# Altium schematic sheet LIDO-HW.100.OutputStage.SchDoc(LIDO-HW.100.OutputStage)
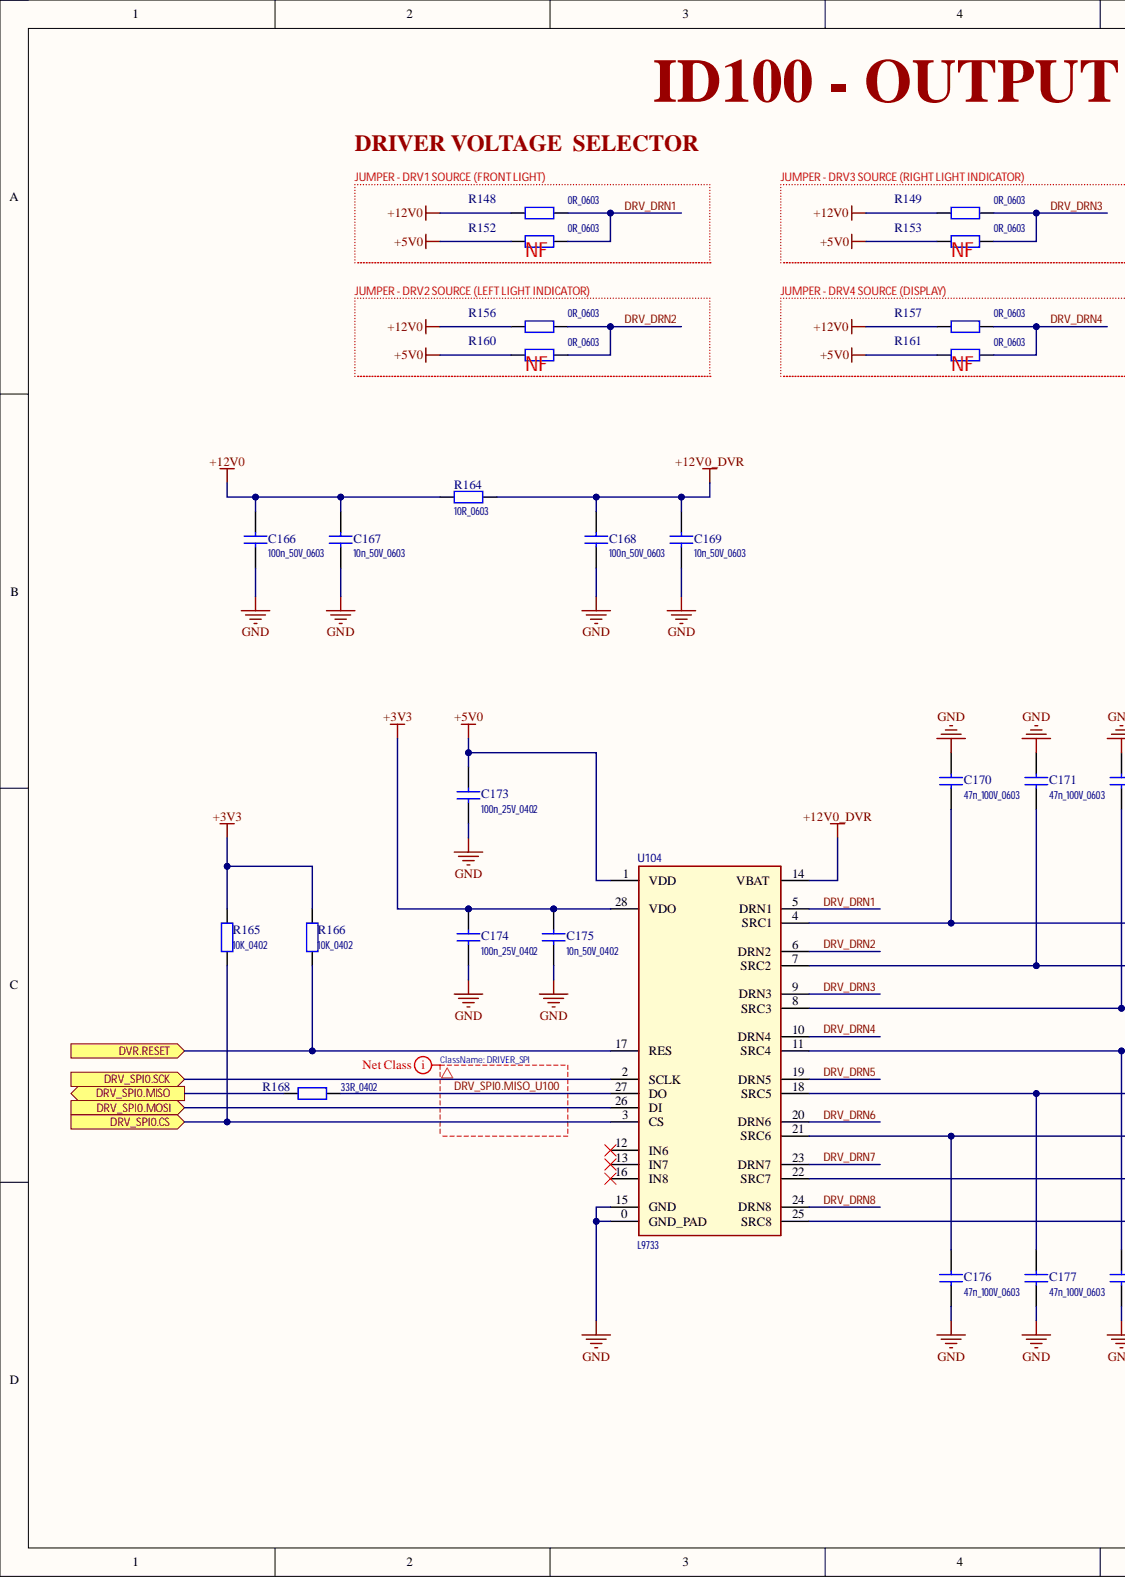
[diagram p0: LIDO-HW.100.OutputStage.SchDoc, left]
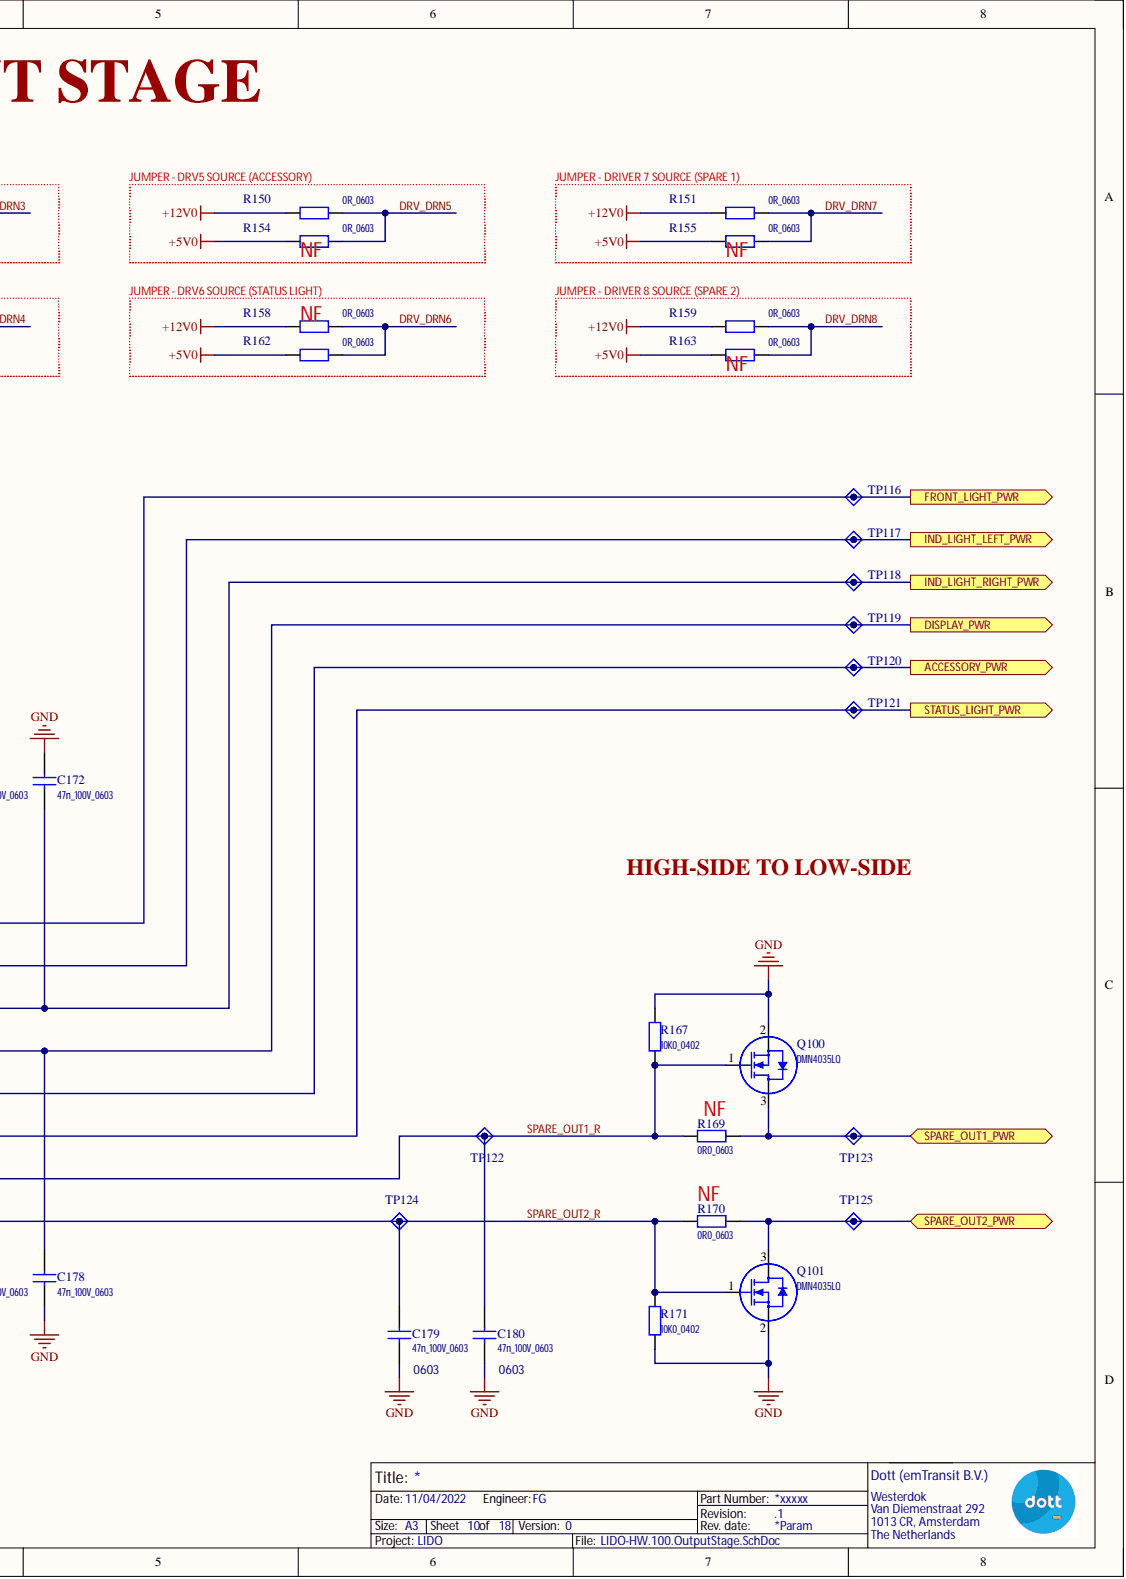
[diagram p1: LIDO-HW.100.OutputStage.SchDoc, right]

## Parts
C166  100n_50V_0603
C167  10n_50V_0603
C168  100n_50V_0603
C169  10n_50V_0603
C170  47n_100V_0603
C171  47n_100V_0603
C172  47n_100V_0603
C173  100n_25V_0402
C174  100n_25V_0402
C175  10n_50V_0402
C176  47n_100V_0603
C177  47n_100V_0603
C178  47n_100V_0603
C179  47n_100V_0603
C180  47n_100V_0603
Q100  DMN4035LQ
Q101  DMN4035LQ
R148  0R_0603
R149  0R_0603
R150  0R_0603
R151  0R_0603
R152  0R_0603
R153  0R_0603
R154  0R_0603
R155  0R_0603
R156  0R_0603
R157  0R_0603
R158  0R_0603
R159  0R_0603
R160  0R_0603
R161  0R_0603
R162  0R_0603
R163  0R_0603
R164  10R_0603
R165  10K_0402
R166  10K_0402
R167  10K0_0402
R168  33R_0402
R169  0R0_0603
R170  0R0_0603
R171  10K0_0402
TP116  TestPoint_PWR  (value not drawn)  (no BOM)
TP117  TestPoint_PWR  (value not drawn)  (no BOM)
TP118  TestPoint_PWR  (value not drawn)  (no BOM)
TP119  TestPoint_PWR  (value not drawn)  (no BOM)
TP120  TestPoint_PWR  (value not drawn)  (no BOM)
TP121  TestPoint_PWR  (value not drawn)  (no BOM)
TP122  TestPoint_PWR  (value not drawn)  (no BOM)
TP123  TestPoint_PWR  (value not drawn)  (no BOM)
TP124  TestPoint_PWR  (value not drawn)  (no BOM)
TP125  TestPoint_PWR  (value not drawn)  (no BOM)
U104  L9733

## Ports
ACCESSORY_PWR, DISPLAY_PWR, DRV_SPI0.CS, DRV_SPI0.MISO, DRV_SPI0.MOSI, DRV_SPI0.SCK, DVR.RESET, FRONT_LIGHT_PWR, IND_LIGHT_LEFT_PWR, IND_LIGHT_RIGHT_PWR, SPARE_OUT1_PWR, SPARE_OUT2_PWR, STATUS_LIGHT_PWR

## Nets
+3V3: C174.1 C175.1 R165.1 R166.1 U104.28(VDO)
+5V0: C173.1 R152.1 R153.1 R154.1 R155.1 R160.1 R161.1 R162.1 R163.1 U104.1(VDD)
+12V0: C166.1 C167.1 R148.1 R149.1 R150.1 R151.1 R156.1 R157.1 R158.1 R159.1 R164.2
+12V0_DVR: C168.1 C169.1 R164.1 U104.14(VBAT)
GND: C166.2 C167.2 C168.2 C169.2 C170.1 C171.1 C172.1 C173.2 C174.2 C175.2 C176.2 C177.2 C178.2 C179.2 C180.2 Q100.2 Q101.2 R167.2 R171.2 U104.0(GND_PAD) U104.15(GND)
ACCESSORY_PWR: C177.1 TP120.1 U104.18(SRC5) ; port ACCESSORY_PWR
DISPLAY_PWR: C178.1 TP119.1 U104.11(SRC4) ; port DISPLAY_PWR
DRV_DRN1: R148.2 R152.2 U104.5(DRN1)
DRV_DRN2: R156.2 R160.2 U104.6(DRN2)
DRV_DRN3: R149.2 R153.2 U104.9(DRN3)
DRV_DRN4: R157.2 R161.2 U104.10(DRN4)
DRV_DRN5: R150.2 R154.2 U104.19(DRN5)
DRV_DRN6: R158.2 R162.2 U104.20(DRN6)
DRV_DRN7: R151.2 R155.2 U104.23(DRN7)
DRV_DRN8: R159.2 R163.2 U104.24(DRN8)
DRV_SPI0.CS: R165.2 U104.3(CS) ; port DRV_SPI0.CS
DRV_SPI0.MISO: R168.2 ; port DRV_SPI0.MISO
DRV_SPI0.MISO_U100: R168.1 U104.27(DO)
DRV_SPI0.MOSI: U104.26(DI) ; port DRV_SPI0.MOSI
DRV_SPI0.SCK: U104.2(SCLK) ; port DRV_SPI0.SCK
DVR.RESET: R166.2 U104.17(RES) ; port DVR.RESET
FRONT_LIGHT_PWR: C170.2 TP116.1 U104.4(SRC1) ; port FRONT_LIGHT_PWR
IND_LIGHT_LEFT_PWR: C171.2 TP117.1 U104.7(SRC2) ; port IND_LIGHT_LEFT_PWR
IND_LIGHT_RIGHT_PWR: C172.2 TP118.1 U104.8(SRC3) ; port IND_LIGHT_RIGHT_PWR
SPARE_OUT1_PWR: Q100.3 R169.1 TP123.1 ; port SPARE_OUT1_PWR
SPARE_OUT1_R: C180.1 Q100.1 R167.1 R169.2 TP122.1 U104.22(SRC7)
SPARE_OUT2_PWR: Q101.3 R170.1 TP125.1 ; port SPARE_OUT2_PWR
SPARE_OUT2_R: C179.1 Q101.1 R170.2 R171.1 TP124.1 U104.25(SRC8)
STATUS_LIGHT_PWR: C176.1 TP121.1 U104.21(SRC6) ; port STATUS_LIGHT_PWR

Not connected: U104 12(IN6) 13(IN7) 16(IN8)

## Library data of the parts
C166-C180 = Ceramic: Ceramic CAP Symbol; Component Kind: Standard; Library Name: 01_Capacitors.SchLib
Q100 Q101 = MOSFET_N_WD_1G_2S_3D: N-Channel MOSFET with Diode; Component Kind: Standard; Library Name: 07_Transistors.SchLib
R148-R171 = Resistor: Resistor Symbol; Component Kind: Standard; Library Name: 02_Resistors.SchLib
TP116-TP125 = TestPoint_A: Test Point Symbol verion A; 00_Name: TP_XXmm; 01_Diameter: 1mm; Library Name: 31_MFG_Elements.SchLib
U104 = L9733_A: Power Switch/Driver 1:8 N-Channel 1A PowerSSO-28 Exposed Pad; Component Kind: Standard; Library Name: 15_IC_PMIC.SchLib
